# Altium schematic sheet ledbrick_driver_toplevel.SchDoc
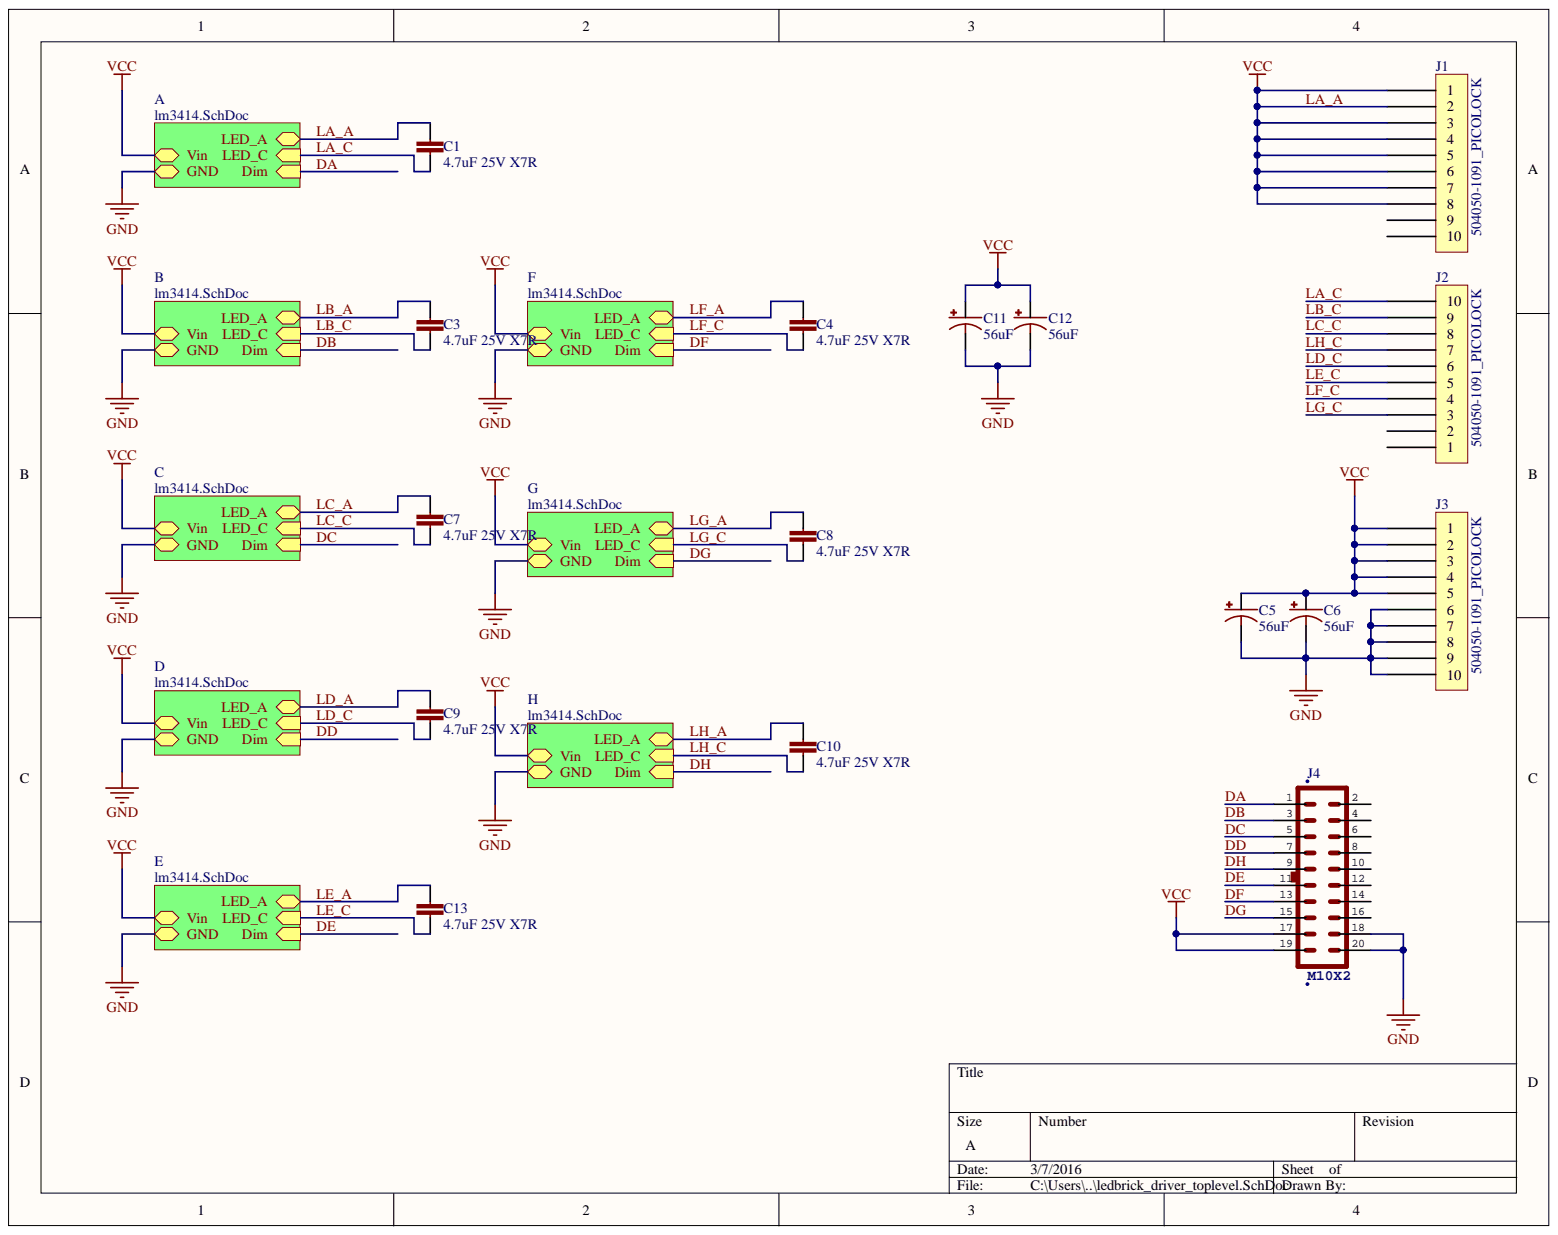
[diagram p0: ledbrick_driver_toplevel.SchDoc]

## Parts
C1  4.7uF 25V X7R  [C1210]
C3  4.7uF 25V X7R  [C1210]
C4  4.7uF 25V X7R  [C1210]
C5  56uF  [PANASONIC_C]
C6  56uF  [PANASONIC_C]
C7  4.7uF 25V X7R  [C1210]
C8  4.7uF 25V X7R  [C1210]
C9  4.7uF 25V X7R  [C1210]
C10  4.7uF 25V X7R  [C1210]
C11  56uF  [PANASONIC_C]
C12  56uF  [PANASONIC_C]
C13  4.7uF 25V X7R  [C1210]
J1  504050-1091_PICOLOCK  [504050-1091_PICOLOCK]
J2  504050-1091_PICOLOCK  [504050-1091_PICOLOCK]
J3  504050-1091_PICOLOCK  [504050-1091_PICOLOCK]
J4  M10X2  [2X10]

## Nets
GND: C5.- C6.- C11.- C12.- J3.6 J3.7 J3.8 J3.9 J3.10 J4.18 J4.20
DA: J4.1
DB: J4.3
DC: J4.5
DD: J4.7
DE: J4.11
DF: J4.13
DG: J4.15
DH: J4.9
LA_A: C1.1 C5.+ C6.+ C11.+ C12.+ J1.1 J1.2 J1.3 J1.4 J1.5 J1.6 J1.7 J1.8 J3.1 J3.2 J3.3 J3.4 J3.5 J4.17 J4.19
LA_C: C1.2 J2.10
LB_A: C3.1
LB_C: C3.2 J2.9
LC_A: C7.1
LC_C: C7.2 J2.8
LD_A: C9.1
LD_C: C9.2 J2.6
LE_A: C13.1
LE_C: C13.2 J2.5
LF_A: C4.1
LF_C: C4.2 J2.4
LG_A: C8.1
LG_C: C8.2 J2.3
LH_A: C10.1
LH_C: C10.2 J2.7
NetJ1_9: J1.9
NetJ1_10: J1.10
NetJ2_1: J2.1
NetJ2_2: J2.2
NetJ4_2: J4.2
NetJ4_4: J4.4
NetJ4_6: J4.6
NetJ4_8: J4.8
NetJ4_10: J4.10
NetJ4_12: J4.12
NetJ4_14: J4.14
NetJ4_16: J4.16

## Library data of the parts
C1 C3 C4 C7-C10 C13 = C-EU: Component Kind: Standard; Library Name: rcl.IntLib
C5 C6 C11 C12 = CPOL-US: Component Kind: Standard; Library Name: rcl.IntLib
J1-J3 = 504050-1091_PICOLOCK: Component Kind: Standard; Library Name: connectors.IntLib
J4 = M10X2: Component Kind: Standard; Library Name: connectors.IntLib
